# Altium schematic sheet Connectors.SchDoc(Connectors)
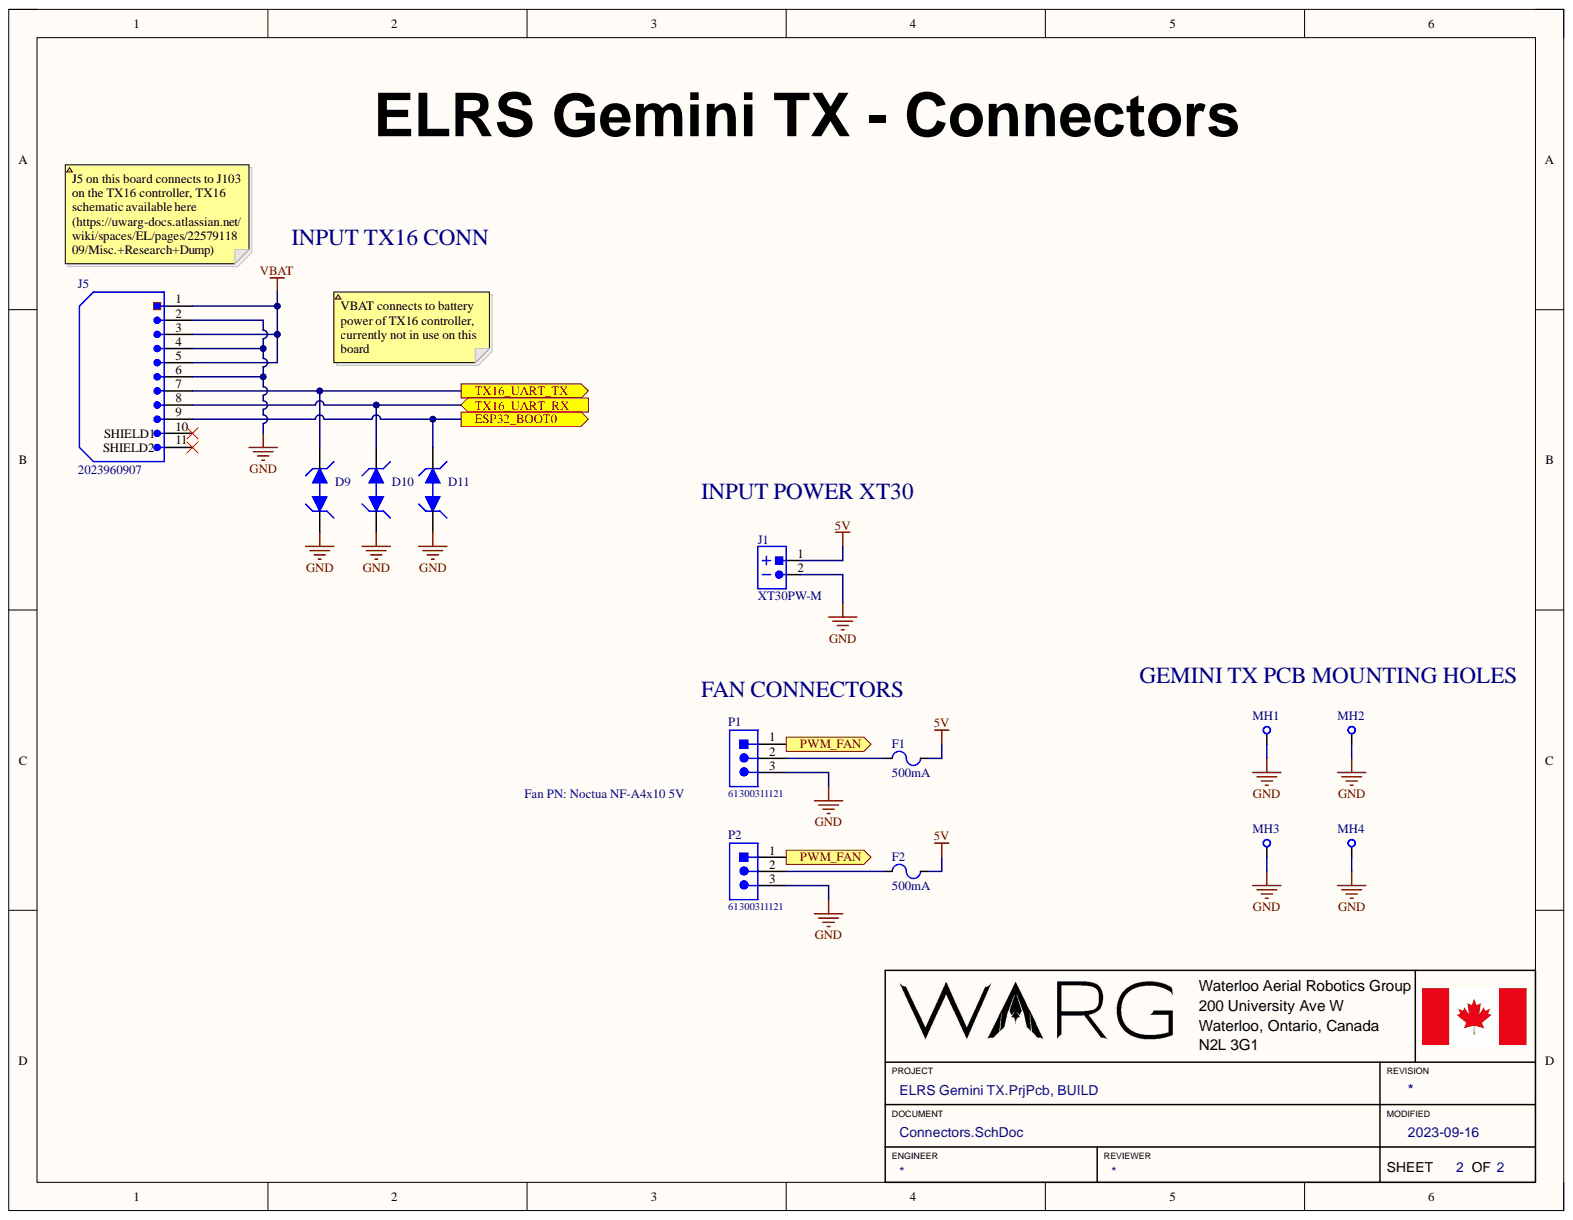
[diagram p0: Connectors.SchDoc]

## Parts
D9  DESD5V0U1BA-7  [DESD5V0U1BA-7-Footprint-1]  (value not drawn)
D10  DESD5V0U1BA-7  [DESD5V0U1BA-7-Footprint-1]  (value not drawn)
D11  DESD5V0U1BA-7  [DESD5V0U1BA-7-Footprint-1]  (value not drawn)
F1  Current Rating: 500mA  [.RES_1206-Footprint-1]  (hidden comment: SF-1206SP050-2)
F2  Current Rating: 500mA  [.RES_1206-Footprint-1]  (hidden comment: SF-1206SP050-2)
J1  XT30PW-M  [XT60PW-M-Footprint-1]
J5  2023960907  [2023960907-Footprint-1]
MH1  M3HOLE-STANDARD  [M3HOLE-STANDARD-Footprint-1]  (value not drawn)
MH2  M3HOLE-STANDARD  [M3HOLE-STANDARD-Footprint-1]  (value not drawn)
MH3  M3HOLE-STANDARD  [M3HOLE-STANDARD-Footprint-1]  (value not drawn)
MH4  M3HOLE-STANDARD  [M3HOLE-STANDARD-Footprint-1]  (value not drawn)
P1  61300311121  [61300311121]
P2  61300311121  [61300311121]

## Ports
ESP32_BOOT0, PWM_FAN, TX16_UART_RX, TX16_UART_TX

## Nets
5V: F1.2 F2.2 J1.1
GND: D9.1 D10.1 D11.1 J1.2 J5.2 J5.4 J5.6 MH1.1 MH2.1 MH3.1 MH4.1 P1.3 P2.3
VBAT: J5.1 J5.3 J5.5
ESP32_BOOT0: D11.2 J5.9 ; port ESP32_BOOT0
PWM_FAN: P1.1 P2.1 ; port PWM_FAN
TX16_UART_RX: D10.2 J5.8 ; port TX16_UART_RX
TX16_UART_TX: D9.2 J5.7 ; port TX16_UART_TX
NetF1_1: F1.1 P1.2
NetF2_1: F2.1 P2.2

Not connected: J5 10(SHIELD1) 11(SHIELD2)

## Library data of the parts
D9-D11 = CMP-00145-5: TVS DIODE, 5V V(RWM), Bidirectional, 1 Element, Silicon; Diode Type: TRANS VOLTAGE SUPPRESSOR; Max Breakdown Voltage: 9.5V; Max Power Dissipation: 250mW; Min Breakdown Voltage: 5.5V; Component Kind: Standard; Max Operating Temperature: 150°C; Min Operating Temperature: -65°C; Polarity: Bidirectional; Rep Pk Reverse Voltage-Max: 5V; Library Name: WARG
F1 F2 = CMP-00156-1: FUSE BOARD MNT 500MA 63VDC 1206; Voltage Rating: 63V; Component Kind: Standard; Mount Type: SMD; Operating Temperature Range: -20°C ~ 105°C; Package Name: 1206; Library Name: WARG
J1 = CMP-00134-1: Board Mount XT30PW-M 2 Pin Male Connector; Component Kind: Standard; Library Name: WARG
J5 = CMP-00162-2: CONN HEADER SMD R/A 9POS 1MM; Manufacturer: Molex; Positions: 9; Component Kind: Standard; Type: Right angle, through hole; Library Name: WARG
MH1-MH4 = CMP-00005-4: Standard M3 Mounting hole as defined by mech team, see confluence for reasonning; Hole Diam: 3.4; Plating Diam: 6; Component Kind: Standard; Library Name: WARG
P1 P2 = CMP-00111-1: CONN HEADER 3 POS 2.54; Connector Type: Male Pin; Number of Positions: 3; Pitch: 0.1inch; Component Kind: Standard; Mount: Through Hole; Library Name: WARG
